# Altium schematic sheet UTHP Edge Connectors.SchDoc("UTHP Edge Connectors")
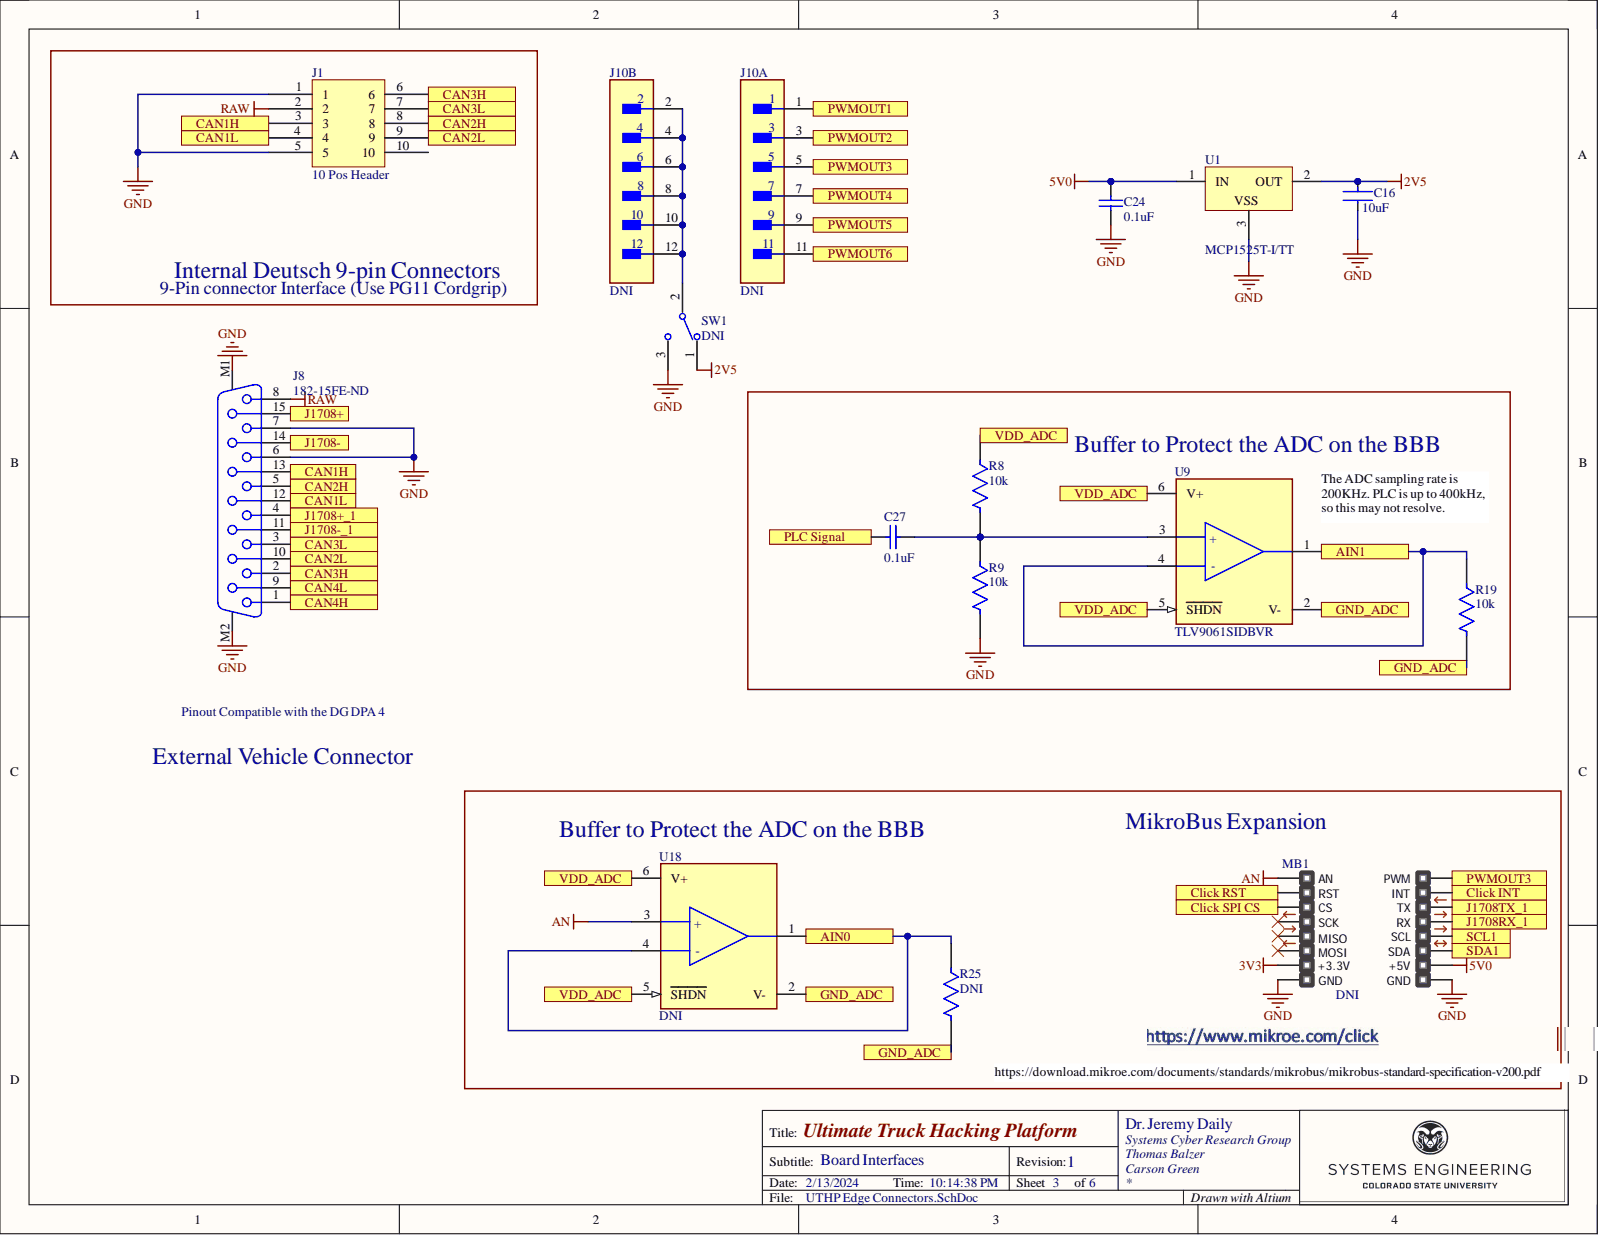
[diagram p0: UTHP Edge Connectors.SchDoc]

## Parts
C16  10uF
C24  0.1uF
C27  0.1uF
J1  10 Pos Header
J8  182-15FE-ND
J10  DNI  (parts A,B)
MB1  DNI  (no BOM)
R8  10k
R9  10k
R19  10k
R25  DNI
SW1  DNI
U1  MCP1525T-I/TT
U9  TLV9061SIDBVR
U18  DNI

## Ports
AIN0, AIN1, CAN1H, CAN1L, CAN2H, CAN2L, CAN3H, CAN3L, CAN4H, CAN4L, Click INT, Click RST, Click SPI CS, GND_ADC, J1708+, J1708+_1, J1708-, J1708-_1, J1708RX_1, J1708TX_1, PLC Signal, PWMOUT1, PWMOUT2, PWMOUT3, PWMOUT4, PWMOUT5, PWMOUT6, SCL1, SDA1, VDD_ADC

## Nets
2V5: C16.1 SW1.1 U1.2
3V3: MB1.7(+3.3V)
5V0: C24.1 MB1.10(+5V) U1.1
AN: MB1.1(AN) U18.3
GND: C16.2 C24.2 J1.1 J1.5 J8.6 J8.7 J8.M1 J8.M2 MB1.8(GND) MB1.9(GND) R9.2 SW1.3 U1.3
RAW: J1.2 J8.8
AIN0: R25.1 U18.1 U18.4 ; port AIN0
AIN1: R19.1 U9.1 U9.4 ; port AIN1
CAN1H: J1.3 J8.13 ; port CAN1H
CAN1L: J1.4 J8.12 ; port CAN1L
CAN2H: J1.8 J8.5 ; port CAN2H
CAN2L: J1.9 J8.10 ; port CAN2L
CAN3H: J1.6 J8.2 ; port CAN3H
CAN3L: J1.7 J8.3 ; port CAN3L
CAN4H: J8.1 ; port CAN4H
CAN4L: J8.9 ; port CAN4L
Click INT: MB1.15(INT) ; port Click INT
Click RST: MB1.2(RST) ; port Click RST
Click SPI CS: MB1.3(CS) ; port Click SPI CS
GND_ADC: R19.2 R25.2 U9.2(V-) U18.2(V-) ; port GND_ADC
J1708+: J8.15 ; port J1708+
J1708+_1: J8.4 ; port J1708+_1
J1708-: J8.14 ; port J1708-
J1708-_1: J8.11 ; port J1708-_1
J1708RX_1: MB1.13(RX) ; port J1708RX_1
J1708TX_1: MB1.14(TX) ; port J1708TX_1
PLC Signal: C27.1 ; port PLC Signal
PWMOUT1: J10.1 ; port PWMOUT1
PWMOUT2: J10.3 ; port PWMOUT2
PWMOUT3: J10.5 MB1.16(PWM) ; port PWMOUT3
PWMOUT4: J10.7 ; port PWMOUT4
PWMOUT5: J10.9 ; port PWMOUT5
PWMOUT6: J10.11 ; port PWMOUT6
SCL1: MB1.12(SCL) ; port SCL1
SDA1: MB1.11(SDA) ; port SDA1
VDD_ADC: R8.1 U9.5(SHDN) U9.6(V+) U18.5(SHDN) U18.6(V+) ; port VDD_ADC
NetC27_2: C27.2 R8.2 R9.1 U9.3
NetJ10_2: J10.2 J10.4 J10.6 J10.8 J10.10 J10.12 SW1.2

Not connected: J1 10; MB1 4(SCK) 5(MISO) 6(MOSI)

## Library data of the parts
C16 = CMP-14477-002214-1: CAP CER 10UF 50V X7R 1210 UMK325AB7106KM-T; Manufacturer: Taiyo Yuden; Component Kind: Standard; Library Name: Altium Content Vault; Part Number: UMK325AB7106KM-T; Supplier 1: Digi-Key; Supplier Part Number 1: 587-3167-1-ND
C24 C27 = CMP-2006-04387-1: 0.1 µF ±10% 50V Ceramic Capacitor X7R 0603 (1608 Metric); Manufacturer: KYOCERA AVX; Part Number: KAM15AR71H104KM; Component Kind: Standard; Library Name: Altium Content Vault; Supplier 1: Digi-Key; Supplier Part Number 1: 478-KAM15AR71H104KMTR-ND; Value: 0.1uF
J1 = CMP-025-000000-1: Connector Header Through Hole, Right Angle 10 position 0.165" (4.20mm); Manufacturer: Molex; Value: Molex 10-pos; Component Kind: Standard; Part Number: 39-30-1100; Supplier 1: Digi-Key; Supplier Part Number 1: WM1355-ND; Library Name: CSU Systems Cyber
J8 = CMP-1502-00205-2: NorComp Inc. Part #: 182-015-213R531        Digikey Part #: 182-15FE-ND        Link: https://www.digikey.com/en/products/detail/norcomp-inc/182-015-213R531/858357; NexarPartId: 22865747; Contact Resistance: 30mΩ; Current Rating: 3A; Gender: Female; Insulation Resistance: 5GΩ; Number of Contacts: 15; Operating Supply Voltage: 125V; Pitch: 2.77mm; Component Kind: Standard; Contact Plating: Gold; Depth: 20.75mm; Housing Material: Steel; Insulation Material: PBT; Length: 24.76mm; Library Name: Altium Content Vault; Max Operating Temperature: 105°C; Min Operating Temperature: -55°C; Mount: Through Hole; Number of Pins: 15; Number of Rows: 2; Orientation: Right Angle; REACH SVHC: Unknown; RoHS: Compliant; Termination: SMD/SMT; Voltage Rating: 125V; Width: 12.5mm
J10 = CMP-00257-00249961-1: 70246-1201 Conn Shrouded Header (4 Sides) HDR 12 POS 2.54mm Solder ST Top Entry Thru-Hole C-Grid® Tray; NexarPartId: 249961; Connector Type: Male Pin; Current Rating: 2.5A; Gender: Male; Glow Wire Compliant: Non-compliant; Max Voltage Rating (DC): 250V; Number of Circuits: 12; Color: Black; Component Kind: Standard; Contact Material: Bronze, Gold, Nickel; Contact Mating Length: 6.096mm; Contact Plating: Gold; Depth: 8.89mm; ELV: Compliant; Flammability Rating: UL94 V-0; Height: 9.47mm; Housing Color: Black; Housing Material: Polyester; Lead Free: Lead Free; Length: 22.86mm; Library Name: Altium Content Vault; Max Operating Temperature: 120°C; Min Operating Temperature: -55°C; Mount: Through Hole; Number of Contacts: 12; Number of Positions: 12; Number of Rows: 2; Orientation: Vertical; Pitch: 2.54mm; REACH SVHC: No SVHC; RoHS: Compliant; Row Spacing: 2.54mm; Termination: Solder; Voltage Rating: 250V
MB1 = CMP-068-000000-1: mikroBUS compatible add-on board; Library Name: CSU Systems Cyber
R8 R9 R19 = CMP-08839-030382-1: 10 kOhms ±1% 0.1W, 1/10W Chip Resistor 0603 (1608 Metric) Automotive AEC-Q200, High Voltage Thick Film; Manufacturer: Rohm Semiconductor; Part Number: KTR03EZPF1002; Component Kind: Standard; Library Name: Altium Content Vault; Supplier 1: Digi-Key; Supplier Part Number 1: RHM10.0KAYCT-ND; Value: 10k
R25 = CMP-08839-030382-1: 10 kOhms ±1% 0.1W, 1/10W Chip Resistor 0603 (1608 Metric) Automotive AEC-Q200, High Voltage Thick Film; Manufacturer: Rohm Semiconductor; Part Number: KTR03EZPF1002; Value: 10k; Component Kind: Standard; Library Name: Altium Content Vault; Supplier 1: Digi-Key; Supplier Part Number 1: RHM10.0KAYCT-ND
SW1 = CMP-31746-000002-1: SWITCH SLIDE SPDT 3A 125V; Actuator Length: 5.1mm; Actuator Material: Nylon; Actuator Type: Standard; Contact Style: Non-Shorting; Electrical Life: 20000Cycles; Illumination Type: Non-Illuminated; Max Voltage Rating (AC): 250V; Mechanical Life: 50000Cycles; Switch Function: On-On; Switch Travel: 2.2mm; Component Kind: Standard; Contact Current Rating: 3A; Contact Material: Copper; Contact Plating: Silver; Current Rating: 3A; Depth: 7.5mm; Flammability Rating: UL94 V-0; Lead Free: Lead Free; Length: 14mm; Library Name: Altium Content Vault; Max Current Rating: 3A; Max Operating Temperature: 85°C; Min Operating Temperature: -30°C; Mount: Through Hole; Orientation: Vertical; Package Quantity: 1; Packaging: Tray; Primary Type: SWITCH; Reach SVHC Compliant: Yes; RoHS Compliant: Yes; Series: CS; Termination: PC Pin; Throw Configuration: SPDT; Voltage Rating (AC): 125V
U1 = CMP-0131-00003-1: 2.5V Voltage Reference, 3-Pin SOT-23, Industrial Temperature, Tape and Reel; Code_IPC: SOT23-3; Component Kind: Standard; DatasheetVersion: revB, Feb-2005; Library Name: Altium Content Vault; PackageDescription: 3-Lead Plastic Small Outline Transistor (TT) [SOT-23]; PackageReference: SOT-23-TT3; PackageVersion: revBB, Aug-2009
U9 U18 = CMP-04895-000376-1: IC CMOS 1 CIRCUIT SOT23-6; Component Kind: Standard; Library Name: Altium Content Vault; RoHS Compliant: Yes
